# Altium schematic sheet JGL1905_Xyloni_Power&Clock&Memory_V1.06.SchDoc
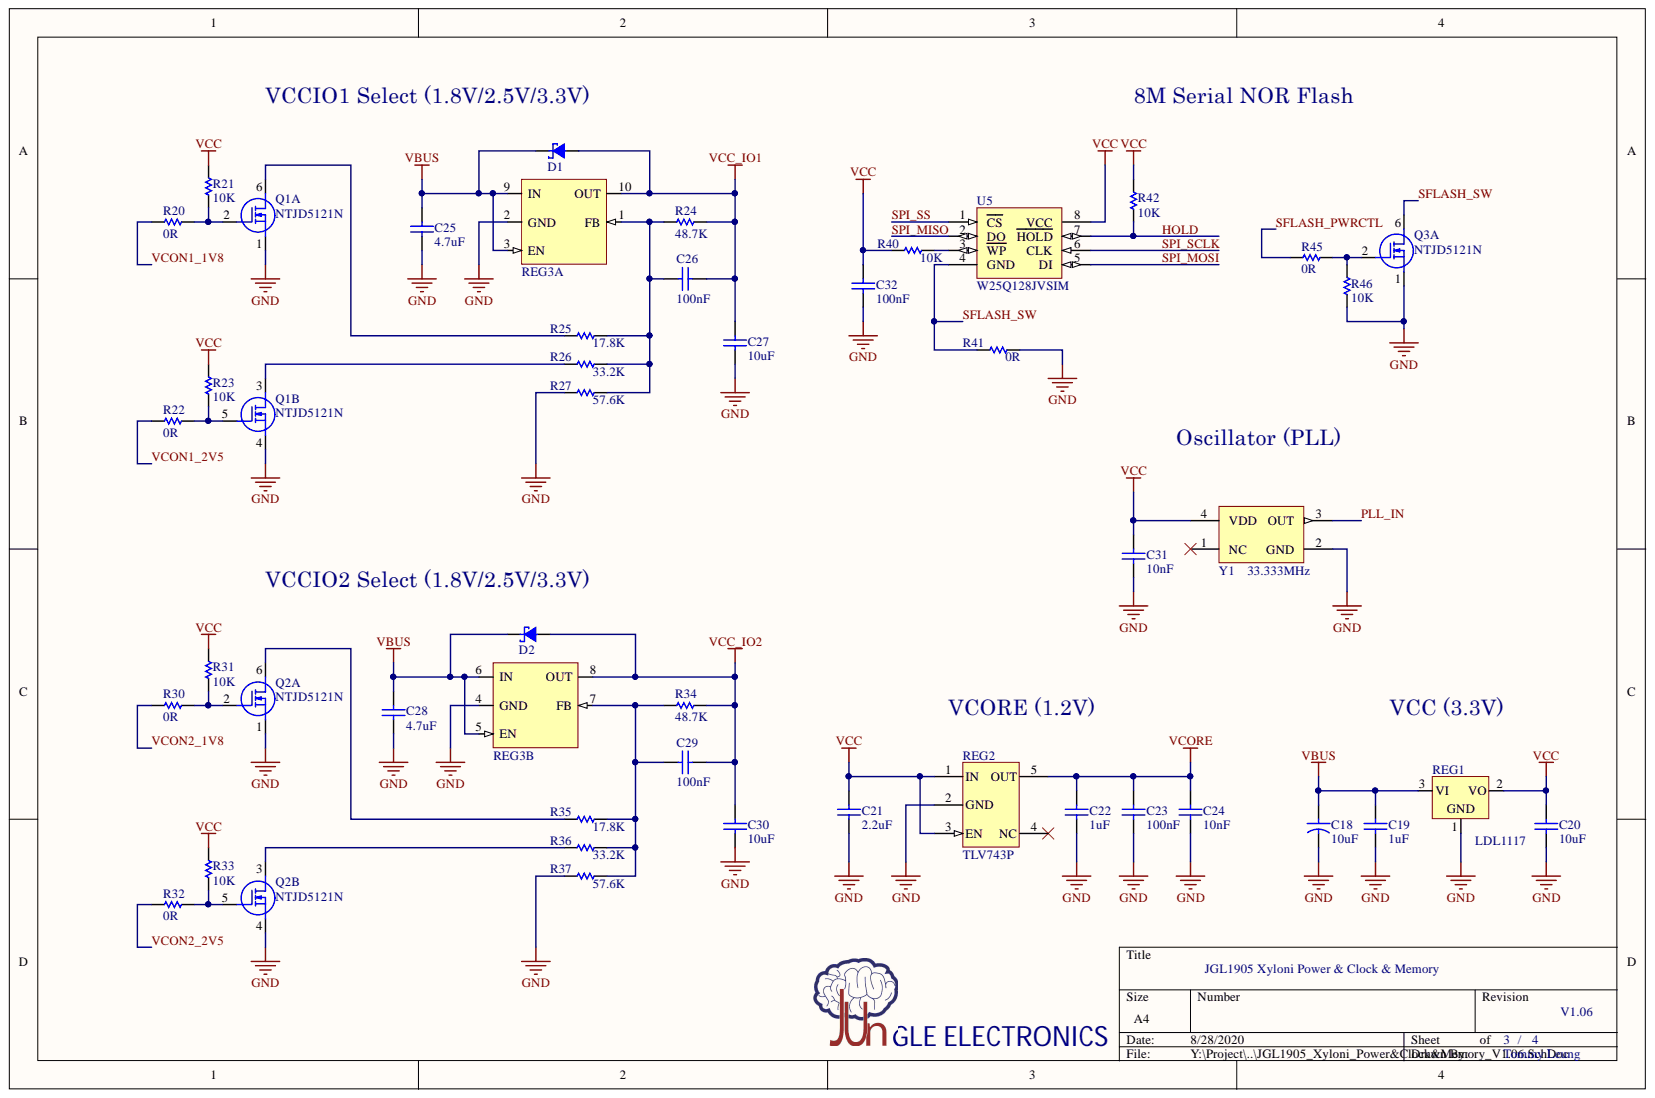
[diagram p0: JGL1905_Xyloni_Power&Clock&Memory_V1.06.SchDoc]

## Parts
C18  value 10uF  [TANCAP-A]  (hidden comment: CAPACITOR)
C19  value 1uF  [CAPC0402M]  (hidden comment: CAPACITOR)
C20  value 10uF  [CAPC0402M]  (hidden comment: CAPACITOR)
C21  value 2.2uF  [CAPC0402M]  (hidden comment: CAPACITOR)
C22  value 1uF  [CAPC0402M]  (hidden comment: CAPACITOR)
C23  value 100nF  [CAPC0402M]  (hidden comment: CAPACITOR)
C24  value 10nF  [CAPC0402M]  (hidden comment: CAPACITOR)
C25  value 4.7uF  [CAPC0402M]  (hidden comment: CAPACITOR)
C26  value 100nF  [CAPC0402M]  (hidden comment: CAPACITOR)
C27  value 10uF  [CAPC0402M]  (hidden comment: CAPACITOR)
C28  value 4.7uF  [CAPC0402M]  (hidden comment: CAPACITOR)
C29  value 100nF  [CAPC0402M]  (hidden comment: CAPACITOR)
C30  value 10uF  [CAPC0402M]  (hidden comment: CAPACITOR)
C31  value 10nF  [CAPC0402M]  (hidden comment: CAPACITOR)
C32  value 100nF  [CAPC0402M]  (hidden comment: CAPACITOR)
D1  Schottky Diode  [SOD-323]  (value not drawn)
D2  Schottky Diode  [SOD-323]  (value not drawn)
Q1  NTJD5121N  [SOT-363]  (parts A,B)
Q2  NTJD5121N  [SOT-363]  (parts A,B)
Q3  NTJD5121N  [SOT-363]  (part A)
R20  value 0R  [RESC0402M]  (hidden comment: RESISTOR)
R21  value 10K  [RESC0402M]  (hidden comment: RESISTOR)
R22  value 0R  [RESC0402M]  (hidden comment: RESISTOR)
R23  value 10K  [RESC0402M]  (hidden comment: RESISTOR)
R24  value 48.7K  [RESC0402M]  (hidden comment: RESISTOR)
R25  value 17.8K  [RESC0402M]  (hidden comment: RESISTOR)
R26  value 33.2K  [RESC0402M]  (hidden comment: RESISTOR)
R27  value 57.6K  [RESC0402M]  (hidden comment: RESISTOR)
R30  value 0R  [RESC0402M]  (hidden comment: RESISTOR)
R31  value 10K  [RESC0402M]  (hidden comment: RESISTOR)
R32  value 0R  [RESC0402M]  (hidden comment: RESISTOR)
R33  value 10K  [RESC0402M]  (hidden comment: RESISTOR)
R34  value 48.7K  [RESC0402M]  (hidden comment: RESISTOR)
R35  value 17.8K  [RESC0402M]  (hidden comment: RESISTOR)
R36  value 33.2K  [RESC0402M]  (hidden comment: RESISTOR)
R37  value 57.6K  [RESC0402M]  (hidden comment: RESISTOR)
R40  value 10K  [RESC0402M]  (hidden comment: RESISTOR)
R41  value 0R  [RESC0402M]  (hidden comment: RESISTOR)
R42  value 10K  [RESC0402M]  (hidden comment: RESISTOR)
R45  value 0R  [RESC0402M]  (hidden comment: RESISTOR)
R46  value 10K  [RESC0402M]  (hidden comment: RESISTOR)
REG1  LDL1117  [SOT-223]
REG2  TLV743P  [SOT-23-5]
REG3  TLV752  [WSON-10]  (value not drawn)  (parts A,B)
U5  W25Q128JVSIM  [SOIC-8]
Y1  value 33.333MHz  [XTAL-3225]  (hidden comment: XTAL_SO3225)

## Nets
GND: C18.2 C19.2 C20.2 C21.2 C22.2 C23.1 C24.1 C25.1 C27.2 C28.1 C30.2 C31.2 C32.1 Q1.1 Q1.4 Q2.1 Q2.4 Q3.1 R27.1 R37.1 R41.2 R46.2 REG1.1 REG2.2(GND) REG3.2(GND) REG3.4(GND) REG3.11 Y1.2(GND)
VBUS: C18.1 C19.1 C25.2 C28.2 D1.1 D2.1 REG1.3 REG3.3(EN) REG3.5(EN) REG3.6(IN) REG3.9(IN)
VCC: C20.1 C21.1 C31.1 C32.2 R21.1 R23.2 R31.1 R33.1 R40.1 R42.1 REG1.2 REG2.1(IN) REG2.3(EN) U5.8(VCC) Y1.4(VDD)
VCC_IO1: C26.2 C27.1 D1.2 R24.1 REG3.10(OUT)
VCC_IO2: C29.2 C30.1 D2.2 R34.1 REG3.8(OUT)
VCORE: C22.1 C23.2 C24.2 REG2.5(OUT)
HOLD: R42.2 U5.7(HOLD)
PLL_IN: Y1.3(OUT)
SFLASH_PWRCTL: R45.1
SFLASH_SW: Q3.6 R41.1 U5.4(GND)
SPI_MISO: U5.2(DO)
SPI_MOSI: U5.5(DI)
SPI_SCLK: U5.6(CLK)
SPI_SS: U5.1(CS)
VCON1_1V8: R20.1
VCON1_2V5: R22.1
VCON2_1V8: R30.1
VCON2_2V5: R32.1
NetC26_1: C26.1 R24.2 R25.2 R26.2 R27.2 REG3.1(FB)
NetC29_1: C29.1 R34.2 R35.2 R36.2 R37.2 REG3.7(FB)
NetQ1_2: Q1.2 R20.2 R21.2
NetQ1_3: Q1.3 R26.1
NetQ1_5: Q1.5 R22.2 R23.1
NetQ1_6: Q1.6 R25.1
NetQ2_2: Q2.2 R30.2 R31.2
NetQ2_3: Q2.3 R36.1
NetQ2_5: Q2.5 R32.2 R33.2
NetQ2_6: Q2.6 R35.1
NetQ3_2: Q3.2 R45.2 R46.1
NetR40_2: R40.2 U5.3(WP)

Not connected: REG2 4(NC); Y1 1(NC)

## Library data of the parts
C18 = CAPACITOR_POL: Polarized Capacitor; Mfr. PN: 293D106X96R3A2TE3; Component Kind: Standard; Supplier 1: Mouser; Supplier Part Number 1: 74-293D106X96R3A2TE3; Library Name: Jungle_ADLibrary.IntLib
C19 C22 = CAPACITOR: Mfr. PN: GCM155C71A105KE8D; Component Kind: Standard; Description: Capacitor; Supplier 1: Mouser; Supplier Part Number 1: 81-GCM155C71A105KE8D; Library Name: Jungle_ADLibrary.IntLib
C20 C27 C30 = CAPACITOR: Mfr. PN: GRM155C80J106ME1J; Component Kind: Standard; Description: Capacitor; Supplier 1: Mouser; Supplier Part Number 1: 81-GRM155C80J106ME1J; Library Name: Jungle_ADLibrary.IntLib
C21 = CAPACITOR: Mfr. PN: LMK105BJ225KV-F; Component Kind: Standard; Description: Capacitor; Supplier 1: Mouser; Supplier Part Number 1: 963-LMK105BJ225KV-F; Library Name: Jungle_ADLibrary.IntLib
C23 C26 C29 C32 = CAPACITOR: Mfr. PN: EMK105B7104KV-F; Component Kind: Standard; Description: Capacitor; Supplier 1: Mouser; Supplier Part Number 1: 963-EMK105B7104KV-F; Library Name: Jungle_ADLibrary.IntLib
C24 C31 = CAPACITOR: Mfr. PN: GCM155R71H103KA5J; Component Kind: Standard; Description: Capacitor; Supplier 1: Mouser; Supplier Part Number 1: 81-GCM155R71H103KA5J; Library Name: Jungle_ADLibrary.IntLib
C25 C28 = CAPACITOR: Mfr. PN: GRM155R60J475ME7J; Component Kind: Standard; Description: Capacitor; Supplier 1: Mouser; Supplier Part Number 1: 81-GRM155R60J475ME7J; Library Name: Jungle_ADLibrary.IntLib
D1 D2 = SCHOTTKY_DIODE: Mfr. PN: BAT54J,115; Component Kind: Standard; Description: Schottky Diode; Supplier 1: Mouser; Supplier Part Number 1: 771-BAT54J115; Library Name: Jungle_ADLibrary.IntLib
Q1-Q3 = NTJD5121N: MOSFET – Power, Dual,  N-Channel With ESD  Protection, SC-88  60 V, 295 mA; Component Kind: Standard; Mfr. PN: NTJD5121N; Supplier 1: Mouser; Supplier Part Number 1: 863-NTJD5121NT1G; Value: NTJD5121N; Library Name: Jungle_ADLibrary.IntLib
R20 R22 R30 R32 R41 R45 = RESISTOR: Mfr. PN: RC0402FR-070RL; Component Kind: Standard; Description: Resistor; Supplier 1: Mouser; Supplier Part Number 1: 603-RC0402FR-070RL; Library Name: Jungle_ADLibrary.IntLib
R21 R23 R31 R33 R40 R42 R46 = RESISTOR: Mfr. PN: RC0402FR-0710KL; Component Kind: Standard; Description: Resistor; Supplier 1: Mouser; Supplier Part Number 1: 603-RC0402FR-0710KL; Library Name: Jungle_ADLibrary.IntLib
R24 R34 = RESISTOR: Mfr. PN: RC0402FR-0748K7L; Component Kind: Standard; Description: Resistor; Supplier 1: Mouser; Supplier Part Number 1: 603-RC0402FR-0748K7L; Library Name: Jungle_ADLibrary.IntLib
R25 R35 = RESISTOR: Mfr. PN: RC0402FR-0717K8L; Component Kind: Standard; Description: Resistor; Supplier 1: Mouser; Supplier Part Number 1: 603-RC0402FR-0717K8L; Library Name: Jungle_ADLibrary.IntLib
R26 R36 = RESISTOR: Mfr. PN: RC0402FR-0733K2L; Component Kind: Standard; Description: Resistor; Supplier 1: Mouser; Supplier Part Number 1: 603-RC0402FR-0733K2L; Library Name: Jungle_ADLibrary.IntLib
R27 R37 = RESISTOR: Mfr. PN: RC0402FR-0757K6L; Component Kind: Standard; Description: Resistor; Supplier 1: Mouser; Supplier Part Number 1: 603-RC0402FR-0757K6L; Library Name: Jungle_ADLibrary.IntLib
REG1 = LDL1117: 1.2A High PSRR Low-Dropout Linear Voltage Regulator; Mfr. PN: LDL1117S33R; Component Kind: Standard; Supplier 1: Mouser; Supplier Part Number 1: 511-LDL1117S33R; Library Name: Jungle_ADLibrary.IntLib
REG2 = TLV743P: Capacitor-Free, 300mA, Low-Dropout Regulator; Mfr. PN: TLV74312PDBVR; Component Kind: Standard; Supplier 1: Mouser; Supplier Part Number 1: 595-TLV74312PDBVR; Value: TLV743P; Library Name: Jungle_ADLibrary.IntLib
REG3 = TLV752: TLV752 Dual, 1-A, High-Accuracy, Adjustable-LDO in a Small-Size Package; Mfr. PN: TLV75201PDSQR; Component Kind: Standard; Supplier 1: Mouser; Supplier Part Number 1: 595-TLV75201PDSQR; Library Name: Jungle_ADLibrary.IntLib
U5 = W25Q80DV: 3V 8M-bit Serial Flash Memory; Component Kind: Standard; Mfr. PN: W25Q128JVSIM; Supplier 1: Mouser; Supplier Part Number 1: 454-W25Q128JVSIM; Library Name: Jungle_ADLibrary.IntLib
Y1 = XTAL_SO3225A: Crystal Oscillator, SO3225, 33.333M, -+50ppm(-40~+85), 3.3V; Mfr. PN: ASE-33.333MHZ-L-C-T; Component Kind: Standard; Supplier 1: Mouser; Supplier Part Number 1: 815-ASE33.333MHZLCT; Library Name: Jungle_ADLibrary.IntLib
